# Altium schematic sheet MCU.SchDoc(MCU)
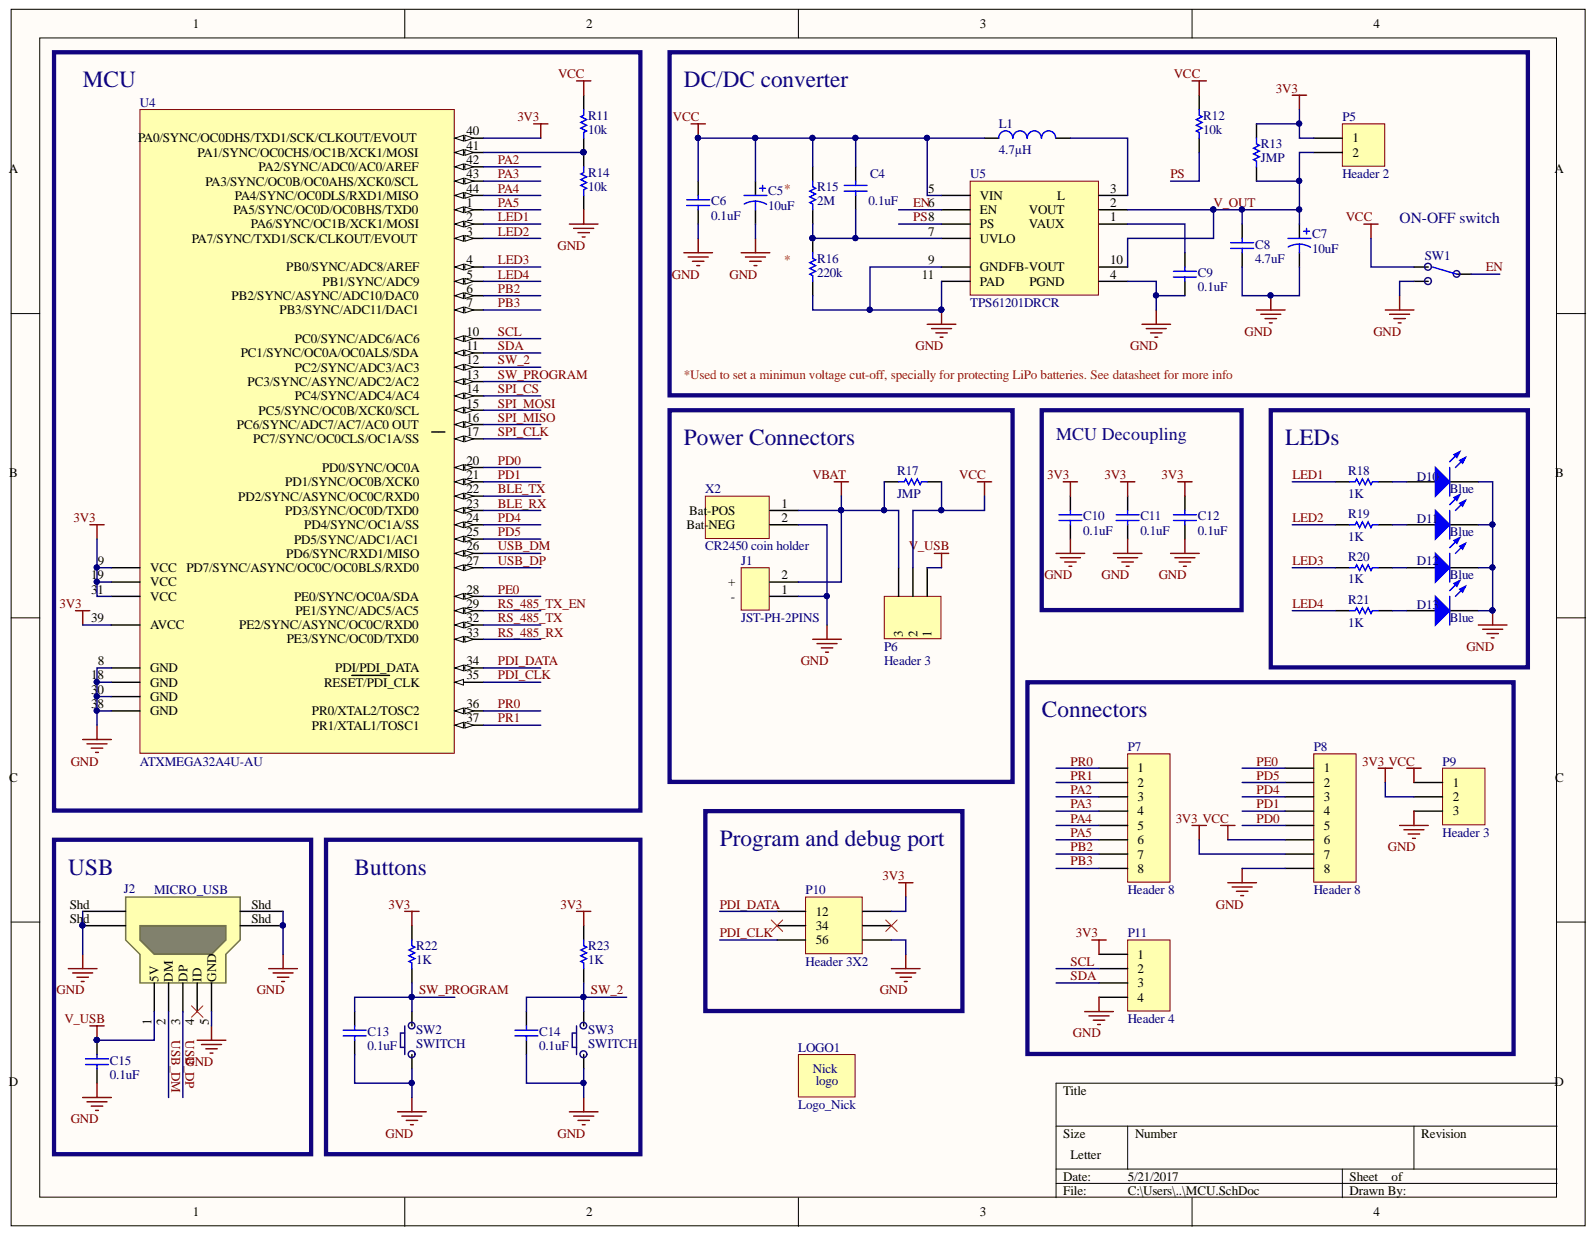
[diagram p0: MCU.SchDoc]

## Parts
C4  0.1uF  [C0603_N]
C5  10uF  [C_TANT_A_3216_N]
C6  0.1uF  [C0603_N]
C7  10uF  [C_TANT_A_3216_N]
C8  4.7uF  [C0603_N]
C9  0.1uF  [C0603_N]
C10  0.1uF  [C0603_N]
C11  0.1uF  [C0603_N]
C12  0.1uF  [C0603_N]
C13  0.1uF  [C0603_N]
C14  0.1uF  [C0603_N]
C15  0.1uF  [C0603_N]
D10  Blue  [R0603_N]
D11  Blue  [R0603_N]
D12  Blue  [R0603_N]
D13  Blue  [R0603_N]
J1  JST-PH-2PINS  [JST-PH-2]
J2  MICRO_USB  [USB MICRO]
L1  4.7µH  [IND_WIRE_murata_N]
LOGO1  Logo_Nick  [logo_nick_400]
P5  Header 2  [HDR1X2]
P6  Header 3  [HDR1X3]
P7  Header 8  [HDR1X8]
P8  Header 8  [HDR1X8]
P9  Header 3  [HDR1X3]
P10  Header 3X2  [HDR2X3]
P11  Header 4  [HDR1X4]
R11  10k  [R0603_N]
R12  10k  [R0603_N]
R13  JMP  [R0603_N]
R14  10k  [R0603_N]
R15  2M  [R0603_N]
R16  220k  [R0603_N]
R17  JMP  [R0603_N]
R18  1K  [R0603_N]
R19  1K  [R0603_N]
R20  1K  [R0603_N]
R21  1K  [R0603_N]
R22  1K  [R0603_N]
R23  1K  [R0603_N]
SW1  SWITCH SLIDE SPDT  [SWITCH_RIGHT_ANGLE_TH]  (value not drawn)
SW2  SWITCH  [swich_foot]
SW3  SWITCH  [swich_foot]
U4  ATXMEGA32A4U-AU  [44A_N]
U5  TPS61201DRCR  [VSON10_M]
X2  CR2450 coin holder  [CR2450 holder]

## Nets
3V3: C10.2 C11.2 C12.2 P5.1 P8.7 P9.2 P10.2 P11.1 R13.2 R22.2 R23.2 U4.9(VCC) U4.19(VCC) U4.31(VCC) U4.39(AVCC) U4.40
GND: C5.2 C6.1 C7.2 C8.1 C9.1 C10.1 C11.1 C12.1 C13.2 C14.2 C15.1 D10.2 D11.2 D12.2 D13.2 J1.1 J2.5(GND) J2.Shd P8.8 P9.3 P10.6 P11.4 R14.1 R16.1 SW1.3 SW2.1 SW3.1 U4.8(GND) U4.18(GND) U4.30(GND) U4.38(GND) U5.4 U5.9 U5.11(PAD) X2.2
V_USB: C15.2 J2.1(5V) P6.1
VBAT: J1.2 P6.3 R17.1 X2.1
VCC: C4.2 C5.1 C6.2 L1.1 P6.2 P8.6 P9.1 R11.2 R12.2 R15.2 R17.2 SW1.1 U5.5(VIN)
BLE_RX: U4.23
BLE_TX: U4.22
EN: SW1.2 U5.6(EN)
LED1: R18.2 U4.2
LED2: R19.2 U4.3
LED3: R20.2 U4.4
LED4: R21.2 U4.5
PA2: P7.3 U4.42
PA3: P7.4 U4.43
PA4: P7.5 U4.44
PA5: P7.6 U4.1
PB2: P7.7 U4.6
PB3: P7.8 U4.7
PD0: P8.5 U4.20
PD1: P8.4 U4.21
PD4: P8.3 U4.24
PD5: P8.2 U4.25
PDI_CLK: P10.5 U4.35
PDI_DATA: P10.1 U4.34
PE0: P8.1 U4.28
PR0: P7.1 U4.36
PR1: P7.2 U4.37
PS: R12.1 U5.8(PS)
RS_485_RX: U4.33
RS_485_TX: U4.32
RS_485_TX_EN: U4.29
SCL: P11.2 U4.10
SDA: P11.3 U4.11
SPI_CLK: U4.17
SPI_CS: U4.14
SPI_MISO: U4.16
SPI_MOSI: U4.15
SW_2: C14.1 R23.1 SW3.2 U4.12
SW_PROGRAM: C13.1 R22.1 SW2.2 U4.13
USB_DM: J2.2(DM) U4.26
USB_DP: J2.3(DP) U4.27
V_OUT: C7.1 C8.2 P5.2 R13.1 U5.2 U5.10
NetC4_1: C4.1 R15.1 R16.2 U5.7(UVLO)
NetC9_2: C9.2 U5.1
NetD10_1: D10.1 R18.1
NetD11_1: D11.1 R19.1
NetD12_1: D12.1 R20.1
NetD13_1: D13.1 R21.1
NetL1_2: L1.2 U5.3
NetR11_1: R11.1 R14.2 U4.41

Not connected: J2 4(ID); P10 3 4

## Library data of the parts
C4 C6 C8-C15 = C_SMD: Component Kind: Standard; Library Name: General.IntLib
  C4 C6 C9-C15: Value: 0.1uF
  C8: Value: 4.7uF
C5 C7 = CP_SMD: Component Kind: Standard; Library Name: General.IntLib; Value: 10uF
D10-D13 = LED_SMD: Color: Blue; Component Kind: Standard; Library Name: discrete.SchLib
J1 = JST-PH-2PINS: CONN HEADER PH SIDE 2POS 2MM SMD; Manufacturer: JST Sales America Inc; Manufacturer Part Number: S2B-PH-SM4-TB(LF)(SN); Connector Type: Header, Shrouded; Number of Positions: 2; Number of Positions Loaded: All; Pitch: 0.079" (2.00mm); Category: Connectors, Interconnects; Color: Natural; Component Kind: Standard; ComponentLink1Description: http://www.jst-mfg.com/product/pdf/eng/ePH.pdf; ComponentLink1URL: http://www.jst-mfg.com/product/pdf/eng/ePH.pdf; Contact Finish: Tin; Contact Finish Thickness: -; Contact Mating Length: -; Contact Type: Male Pin; Fastening Type: -; Features: Solder Retention; Library Name: General.IntLib; Mounting Type: Surface Mount, Right Angle; Number of Rows: 1; Packaging: Cut Tape (CT); Pricing 1: 1=0.56, 10=0.521, 50=0.4168, 100=0.3994, 250=0.36472, 500=0.34734 (USD); RoHS: RoHS Compliant; Row Spacing: -; Stock 1: 51442; Supplier 1: Digi-Key; Supplier Part Number 1: 455-1749-1-ND; Termination: Solder
J2 = MICRO_USB: CONN USB MICRO B RECPT SMT R/A; Manufacturer: FCI; Manufacturer Part Number: 10118194-0001LF; Connector Type: USB - micro B; Gender: Receptacle; Number of Contacts: 5; Specifications: USB 2.0; Category: Connectors, Interconnects; Component Kind: Standard; ComponentLink1Description: http://portal.fciconnect.com/Comergent//fci/drawing/10118194.pdf; ComponentLink1URL: http://portal.fciconnect.com/Comergent//fci/drawing/10118194.pdf; Features: Shielded, Solder Retention; Ingress Protection: -; Library Name: General.IntLib; Mounting Type: Surface Mount, Right Angle, Horizontal; Packaging: Cut Tape (CT); Pricing 1: 1=0.46, 10=0.433, 25=0.3712, 50=0.3156, 100=0.3032, 250=0.27224, 500=0.25988 (USD); RoHS: RoHS Compliant; Stock 1: 9139; Supplier 1: Digi-Key; Supplier Part Number 1: 609-4618-1-ND
L1 = LQH3NPN4R7NJ0L: FIXED IND 4.7UH 1.12A 130 MOHM; Manufacturer: Murata Electronics North America; Current - Saturation: 780mA; DC Resistance (DCR): 156 mOhm Max; Frequency - Self Resonant: 65MHz; Frequency - Test: 1MHz; Material - Core: Ferrite; Shielding: Shielded; Tolerance: ±30%; Type: Wirewound; Category: Inductors, Coils, Chokes; Component Kind: Standard; ComponentLink1Description: http://search.murata.co.jp/Ceramy/image/img/PDF/ENG/L0075S0103LQH3NP_J0.pdf; ComponentLink1URL: http://search.murata.co.jp/Ceramy/image/img/PDF/ENG/L0075S0103LQH3NP_J0.pdf; Current Rating: 1.12A; Height - Seated (Max): 0.039" (1.00mm); Library Name: General.IntLib; Manufacturer Part Number: LQH3NPN4R7NJ0L; Mounting Type: Surface Mount; Operating Temperature: -40°C ~ 85°C; Package / Case: 1212 (3030 Metric); Packaging: Cut Tape (CT); Pricing 1: 1=0.43, 10=0.387, 25=0.3436, 50=0.3006, 100=0.2792, 250=0.25772, 500=0.24482 (USD); Q @ Freq: -; Ratings: -; RoHS: RoHS Compliant; Size / Dimension: 0.118" L x 0.118" W (3.00mm x 3.00mm); Stock 1: 11577; Supplier 1: Digi-Key; Supplier Part Number 1: 490-5346-1-ND; Value: 4.7µH
P5 = Header 2: Header, 2-Pin; Component Kind: Standard; LatestRevisionDate: 17-Jul-2002; LatestRevisionNote: Re-released for DXP Platform.; Library Name: Miscellaneous Connectors.IntLib; Signal Integrity: Connector; Publisher: Altium Limited
P6 P9 = Header 3: Header, 3-Pin; Component Kind: Standard; LatestRevisionDate: 17-Jul-2002; LatestRevisionNote: Re-released for DXP Platform.; Library Name: Miscellaneous Connectors.IntLib; Signal Integrity: Connector; Publisher: Altium Limited
P7 P8 = Header 8: Header, 8-Pin; Component Kind: Standard; LatestRevisionDate: 17-Jul-2002; LatestRevisionNote: Re-released for DXP Platform.; Library Name: Miscellaneous Connectors.IntLib; Signal Integrity: Connector; Publisher: Altium Limited
P10 = Header 3X2: Header, 3-Pin, Dual row; Component Kind: Standard; LatestRevisionDate: 17-Jul-2002; LatestRevisionNote: Re-released for DXP Platform.; Library Name: General.IntLib; Signal Integrity: Connector; Publisher: Altium Limited
P11 = Header 4: Header, 4-Pin; Component Kind: Standard; LatestRevisionDate: 17-Jul-2002; LatestRevisionNote: Re-released for DXP Platform.; Library Name: Miscellaneous Connectors.IntLib; Signal Integrity: Connector; Publisher: Altium Limited
R11-R23 = R_SMD: Component Kind: Standard
  R11 R12 R14: Library Name: discrete.SchLib; Value: 10k
  R13 R17: Library Name: General.IntLib; Value: JMP
  R15: Library Name: discrete.SchLib; Value: 2M
  R16: Library Name: discrete.SchLib; Value: 220k
  R18-R23: Library Name: discrete.SchLib; Value: 1K
SW1 = SWITCH SLIDE SPDT: SWITCH SLIDE SPDT 200MA 30V; Manufacturer: TE Connectivity ALCOSWITCH Switches; Manufacturer Part Number: 1825232-1; Actuator Length: 3.00mm; Actuator Material: Polyoxymethylene (Acetal) (POM); Actuator Type: Standard; Contact Timing: Non-Shorting (BBM); Current Rating: 200mA (DC); Electrical Life: 10,000 Cycles; Operating Force: 200gf; Switch Function: On-On; Switch Travel: 2.00mm; Category: Switches; Circuit: SPDT; Component Kind: Standard; ComponentLink1Description: http://www.te.com/commerce/DocumentDelivery/DDEController?Action=srchrtrv&DocNm=1825232&DocType=Customer+Drawing&DocLang=English; ComponentLink1URL: http://www.te.com/commerce/DocumentDelivery/DDEController?Action=srchrtrv&DocNm=1825232&DocType=Customer+Drawing&DocLang=English; Contact Finish: Silver; Contact Material: Copper Alloy; Features: -; Library Name: General.IntLib; Mechanical Life: 10,000 Cycles; Mounting Type: Through Hole, Right Angle; Operating Temperature: -20°C ~ 70°C; Packaging: Bulk; Part Status: Active; Pricing 1: 1=0.28, 10=0.273, 25=0.2676, 50=0.239, 100=0.2276, 250=0.22192, 500=0.18778, 1000=0.18208, 5000=0.15932 (USD); RoHS: RoHS Compliant; Stock 1: 11496; Supplier 1: Digi-Key; Supplier Part Number 1: A107673-ND; Termination Style: PC Pin; Voltage Rating - AC: -; Voltage Rating - DC: 30V
SW2 SW3 = SWITCH: SWITCH TACTILE SPST-NO 0.05A 12V; Manufacturer: TE Connectivity; Manufacturer Part Number: FSM4JSMATR; Actuator Height off PCB, from Pins: 5.00mm; Actuator Material: Polyphenylene Sulfide (PPS); Actuator Orientation: Top Actuated; Actuator Type: Standard; Contact Rating @ Voltage: 0.05A @ 12VDC; Illumination: Non-Illuminated; Mechanical Life: 100,000 Cycles; Operating Force: 160gf; Outline: 6.00mm x 6.00mm; Switch Function: Off-Mom; Switch Travel: 0.25mm; Category: Switches; Circuit: SPST-NO; Component Kind: Standard; ComponentLink1Description: http://www.te.com/commerce/DocumentDelivery/DDEController?Action=srchrtrv&DocNm=2-1437565-7&DocType=Customer+Drawing&DocLang=English; ComponentLink1URL: http://www.te.com/commerce/DocumentDelivery/DDEController?Action=srchrtrv&DocNm=2-1437565-7&DocType=Customer+Drawing&DocLang=English; Features: -; Illumination Type, Color: -; Illumination Voltage (Nominal): -; Ingress Protection: -; Library Name: General.SchLib; Mounting Type: Surface Mount; Operating Temperature: -35°C ~ 85°C; Packaging: Cut Tape (CT); Pricing 1: 1=0.23, 10=0.223, 25=0.2136, 50=0.2038, 100=0.1843, 250=0.1698, 500=0.15524 (USD); RoHS: RoHS Compliant; Stock 1: 33712; Supplier 1: Digi-Key; Supplier Part Number 1: 450-1759-1-ND; Termination Style: Gull Wing
U4 = ATXMEGA32A4U-AU: IC MCU 8BIT 32KB FLASH 44TQFP; Manufacturer: Atmel; Connectivity: I²C, IrDA, SPI, UART/USART, USB; Core Processor: AVR; Core Size: 8/16-Bit; Data Converters: A/D 12x12b, D/A 2x12b; EEPROM Size: 2K x 8; Number of I /O: 34; Oscillator Type: Internal; Peripherals: Brown-out Detect/Reset, DMA, POR, PWM, WDT; Program Memory Size: 32KB (16K x 16); RAM Size: 4K x 8; Speed: 32MHz; Voltage - Supply (Vcc/Vdd): 1.6 V ~ 3.6 V; Category: Integrated Circuits (ICs); Component Kind: Standard; ComponentLink1Description: http://www.atmel.com/Images/Atmel-8387-8-and16-bit-AVR-Microcontroller-XMEGA-A4U_Datasheet.pdf; ComponentLink1URL: http://www.atmel.com/Images/Atmel-8387-8-and16-bit-AVR-Microcontroller-XMEGA-A4U_Datasheet.pdf; Library Name: General.IntLib; Manufacturer Part Number: ATXMEGA32A4U-AU; Operating Temperature: -40°C ~ 85°C; Package / Case: 44-TQFP; Packaging: Tray; Pricing 1: 1=3.43, 25=2.1548, 100=1.9152 (USD); Program Memory Type: FLASH; RoHS: RoHS Compliant; Stock 1: 2077; Supplier 1: Digi-Key; Supplier Part Number 1: ATXMEGA32A4U-AU-ND
U5 = TPS61201DRCR: IC REG BST 3.3V 1.2A SYNC 10SON; Manufacturer: Texas Instruments; Current - Output: 1.2A (Switch); Frequency - Switching: 1.25MHz ~ 1.65MHz; Function: Step-Up; Number of Outputs: 1; Output Configuration: Positive; Output Type: Fixed; Synchronous Rectifier: Yes; Topology: Boost; Voltage - Input (Max): 5.5V; Voltage - Input (Min): 0.3V; Category: Integrated Circuits (ICs); Component Kind: Standard; ComponentLink1Description: http://www.ti.com/lit/ds/symlink/tps61202.pdf; ComponentLink1URL: http://www.ti.com/lit/ds/symlink/tps61202.pdf; Library Name: General.IntLib; Manufacturer Part Number: TPS61201DRCR; Mounting Type: Surface Mount; Operating Temperature: -40°C ~ 85°C (TA); Package / Case: 10-VFDFN Exposed Pad; Packaging: Cut Tape (CT); Pricing 1: 1=2.74, 10=2.457, 100=1.971, 500=1.62, 1000=1.125 (USD); RoHS: RoHS Compliant; Stock 1: 0; Supplier 1: Digi-Key; Supplier Device Package: 10-VSON (3x3); Supplier Part Number 1: 296-44338-1-ND; Voltage - Output (Max): -; Voltage - Output (Min/Fixed): 3.3V
X2 = CR2450 coin holder: RETAINER 2450 COIN CELL SMD; Manufacturer: MPD (Memory Protection Devices); Manufacturer Part Number: BK-877; Battery Cell Size: Coin, 24.5mm; Battery Series: 2450; Battery Type, Function: Coin Cell, Retainer; Number of Cells: 1; Category: Battery Products; Component Kind: Standard; ComponentLink1Description: http://www.memoryprotectiondevices.com/datasheets/BK-877-datasheet.pdf; ComponentLink1URL: http://www.memoryprotectiondevices.com/datasheets/BK-877-datasheet.pdf; Height Above Board: 0.230" (5.85mm); Library Name: General.IntLib; Mounting Type: PCB, Surface Mount; Operating Temperature: -; Part Status: Active; Pricing 1: 1=0.4, 10=0.367, 100=0.3333, 500=0.303, 1000=0.2828 (USD); RoHS: RoHS Compliant; Stock 1: 908; Style: Retainer; Supplier 1: Digi-Key; Supplier Part Number 1: BK-877-ND; Termination Style: SMD (SMT) Tab
LOGO1 = Logo_Nick: Component Kind: Standard; Library Name: General.IntLib
